# Altium schematic sheet AudioM_Power_v1.0.SchDoc
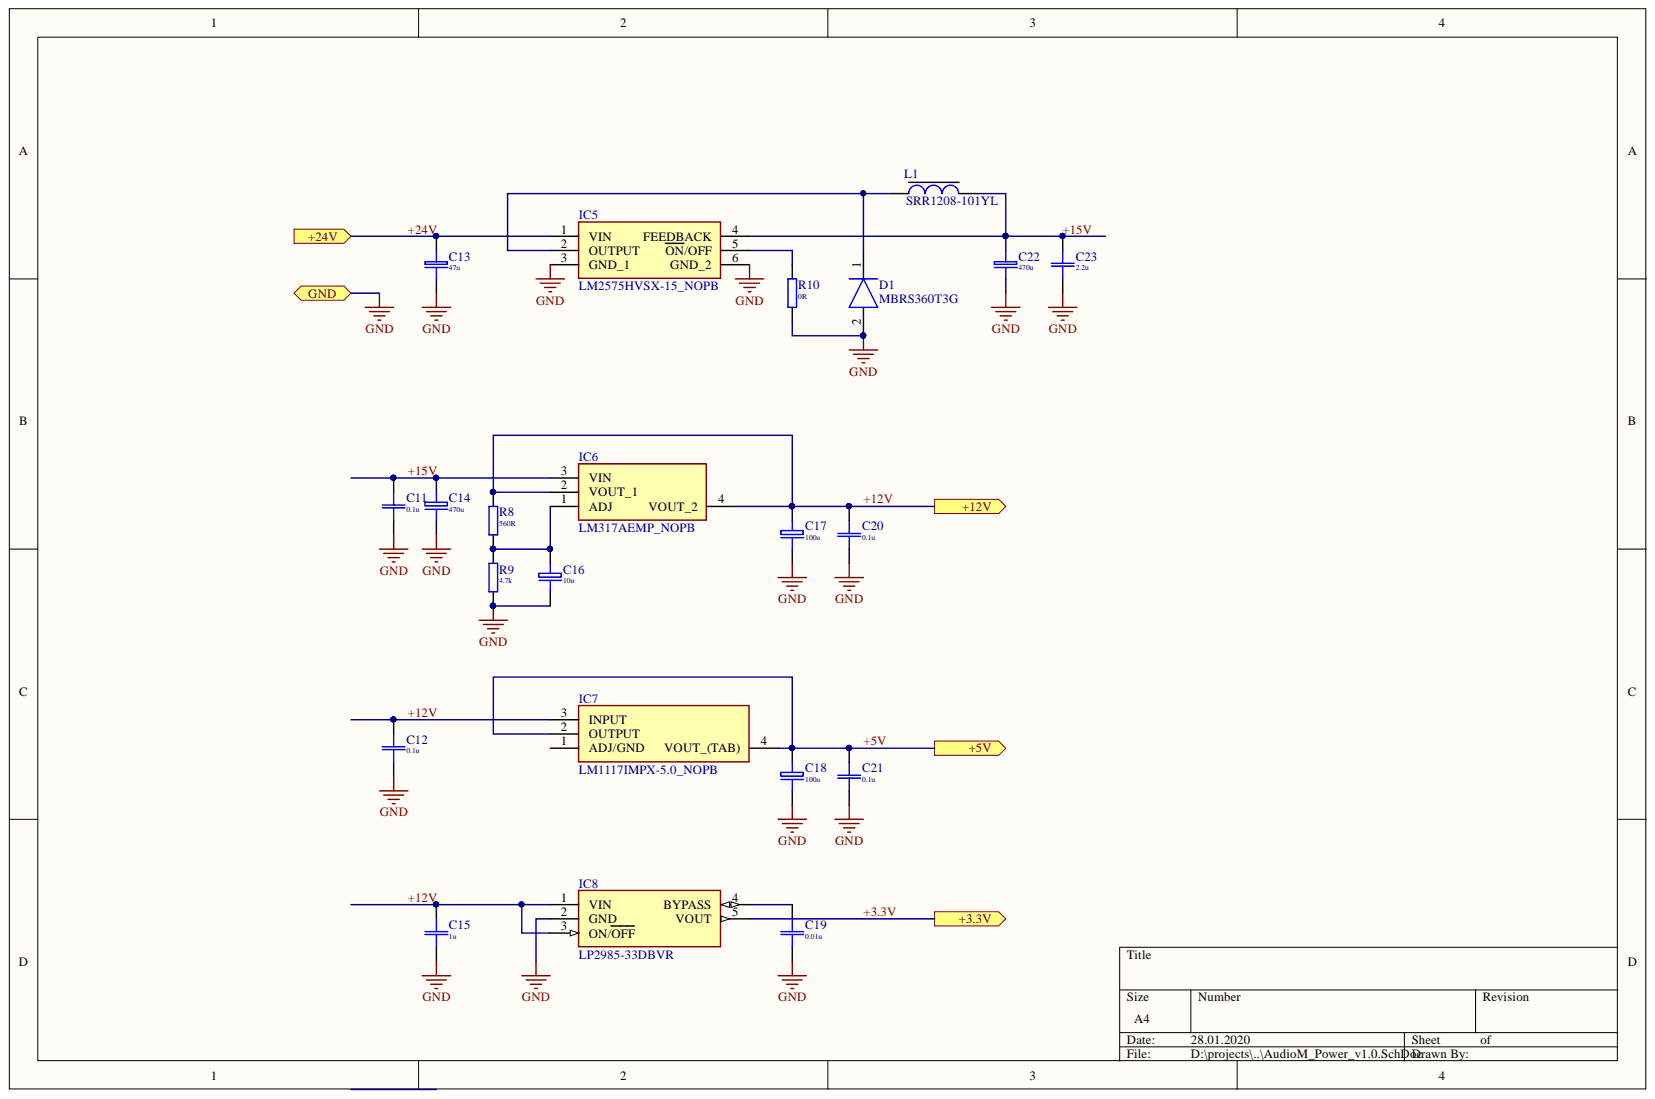
[diagram p0: AudioM_Power_v1.0.SchDoc]

## Parts
C11  0.1u  [C0805(2013)]
C12  0.1u  [C0805(2013)]
C13  47u  [EL SMD Case D D6.3x6.6 h7.7(0605)]
C14  470u  [El-d8-h14-F3.81]
C15  1u  [C0805(2013)]
C16  10u  [El-d5-h11-F2.54]
C17  100u  [El-d8-h14-F3.81]
C18  100u  [El-d8-h14-F3.81]
C19  0.01u  [C0805(2013)]
C20  0.1u  [C0805(2013)]
C21  0.1u  [C0805(2013)]
C22  470u  [EL SMD Case D D6.3x6.6 h7.7(0605)]
C23  2.2u  [C0805(2013)]
D1  MBRS360T3G  [DIOM7958X256N]
IC5  LM2575HVSX-15_NOPB  [KTT0005B]
IC6  LM317AEMP_NOPB  [SOT230P700X180-4N]
IC7  LM1117IMPX-5.0_NOPB  [SOT230P700X180-4N]
IC8  LP2985-33DBVR  [SOT95P280X145-5N]
L1  SRR1208-101YL  [SRR1208]
R8  560R  [R0603]
R9  4.7k  [R0603]
R10  0R  [R0603]

## Ports
+3.3V, +5V, +12V, +24V, GND

## Nets
+3.3V: IC8.5(VOUT) ; port +3.3V
+5V: C18.1 C21.1 IC7.2(OUTPUT) IC7.4(VOUT_(TAB)) ; port +5V
+12V: C12.1 C15.1 C17.1 C20.1 IC6.2(VOUT_1) IC6.4(VOUT_2) IC7.3(INPUT) IC8.1(VIN) IC8.3(ON/OFF) R8.1 ; port +12V
+15V: C11.1 C14.1 C22.1 C23.1 IC5.4(FEEDBACK) IC6.3(VIN) L1.2
+24V: C13.1 IC5.1(VIN) ; port +24V
GND: C11.2 C12.2 C13.2 C14.2 C15.2 C16.2 C17.2 C18.2 C19.2 C20.2 C21.2 C22.2 C23.2 D1.2 IC5.3(GND_1) IC5.6(GND_2) IC8.2(GND) R9.2 R10.2 ; port GND
NetC16_1: C16.1 IC6.1(ADJ) R8.2 R9.1
NetC19_1: C19.1 IC8.4(BYPASS)
NetD1_1: D1.1 IC5.2(OUTPUT) L1.1
NetIC5_5: IC5.5(ON/OFF) R10.1
N00083:

Not connected: IC7 1(ADJ/GND)

## Library data of the parts
C11 C12 C15 C19-C21 C23 = C-0805  100V 10n: SMD-0805 100V X7R 10%; Component Kind: Standard; Library Name: SMD-RCL.IntLib; Price: 0.062; Signal Integrity: Cap; Simulation: Capacitor
  C11 C12 C20 C21: Value: 0.1u
  C15: Value: 1u
  C19: Value: 0.01u
  C23: Value: 2.2u
C13 = EL 100u/25V: EL 25V SMD Case C D6.3x6.6 h7.7; Component Kind: Standard; Library Name: SMD-RCL.IntLib; Price: 0.014; Signal Integrity: Cap; Simulation: Capacitor; Value: 47u
C14 C17 C18 = 470u-25V: 25V; Component Kind: Standard; Library Name: Cap-El.SchLib; Price: 0.15; Signal Integrity: C; Simulation: C
  C14: Value: 470u
  C17 C18: Value: 100u
C16 = 10u-35V: 35V; Component Kind: Standard; Library Name: Cap-El.SchLib; Price: 0.04; Signal Integrity: C; Simulation: C; Value: 10u
C22 = EL 100u/35V-2: EL 35V SMD Case D D6.3x6.6 h7.7(0605); Component Kind: Standard; Library Name: SMD-RCL.IntLib; Price: 0.014; Signal Integrity: Cap; Simulation: Capacitor; Value: 470u
D1 = MBRS360T3G: Diode; Manufacturer_Name: ON Semiconductor; Allied_Number: 70099510; Allied Price/Stock: http://www.alliedelec.com/on-semiconductor-mbrs360t3g/70099510/; Component Kind: Standard; Datasheet Link: http://www.onsemi.com/pub/Collateral/MBRS360T3-D.PDF; Height: 2.56mm; Manufacturer_Part_Number: MBRS360T3G; Mouser Part Number: 863-MBRS360T3G; Mouser Price/Stock: https://www.mouser.com/Search/Refine.aspx?Keyword=863-MBRS360T3G; RS Part Number: 5452096P; RS Price/Stock: http://uk.rs-online.com/web/p/products/5452096P
IC5 = LM2575HVSX-15_NOPB: Integrated Circuit; Manufacturer_Name: Texas Instruments; Manufacturer_Part_Number: LM2575HVSX-15/NOPB; Component Kind: Standard; Datasheet Link: http://www.ti.com/lit/ds/symlink/lm2575-n.pdf; Mouser Part Number: 926-LM2575HVSX15NOPB; Mouser Price/Stock: https://www.mouser.com/Search/Refine.aspx?Keyword=926-LM2575HVSX15NOPB
IC6 = LM317AEMP_NOPB: Integrated Circuit; Manufacturer_Name: Texas Instruments; Manufacturer_Part_Number: LM317AEMP/NOPB; Component Kind: Standard; Datasheet Link: http://www.ti.com/lit/gpn/lm317a; Height: 1.8mm; Mouser Part Number: 926-LM317AEMP/NOPB; Mouser Price/Stock: https://www.mouser.com/Search/Refine.aspx?Keyword=926-LM317AEMP%2FNOPB; RS Part Number: 7615621P; RS Price/Stock: http://uk.rs-online.com/web/p/products/7615621P
IC7 = LM1117IMPX-5.0_NOPB: Undefined or Miscellaneous; Manufacturer_Name: Texas Instruments; Manufacturer_Part_Number: LM1117IMPX-5.0/NOPB; Component Kind: Standard; Datasheet Link: http://www.ti.com/lit/gpn/lm1117; Height: 1.8mm; Mouser Part Number: 926-LM1117IMPX50NOPB; Mouser Price/Stock: https://www.mouser.com/Search/Refine.aspx?Keyword=926-LM1117IMPX50NOPB
IC8 = LP2985-33DBVR: Integrated Circuit; Manufacturer_Name: Texas Instruments; Component Kind: Standard; Datasheet Link: http://www.ti.com/lit/gpn/lp2985; Height: 1.45mm; Manufacturer_Part_Number: LP2985-33DBVR; Mouser Part Number: 595-LP2985-33DBVR; Mouser Price/Stock: https://www.mouser.com/Search/Refine.aspx?Keyword=595-LP2985-33DBVR
R8-R10 = R-0603: SMD 0603 0.0625W 5% 50V; Component Kind: Standard; Library Name: SMD-RCL.IntLib; Price: 0.017; Signal Integrity: Res; Simulation: Resistor
  R8: Value: 560R
  R9: Value: 4.7k
  R10: Value: 0R
L1 = SRR1208-101YL: Component Kind: Standard
